# Altium schematic sheet Schema.SchDoc
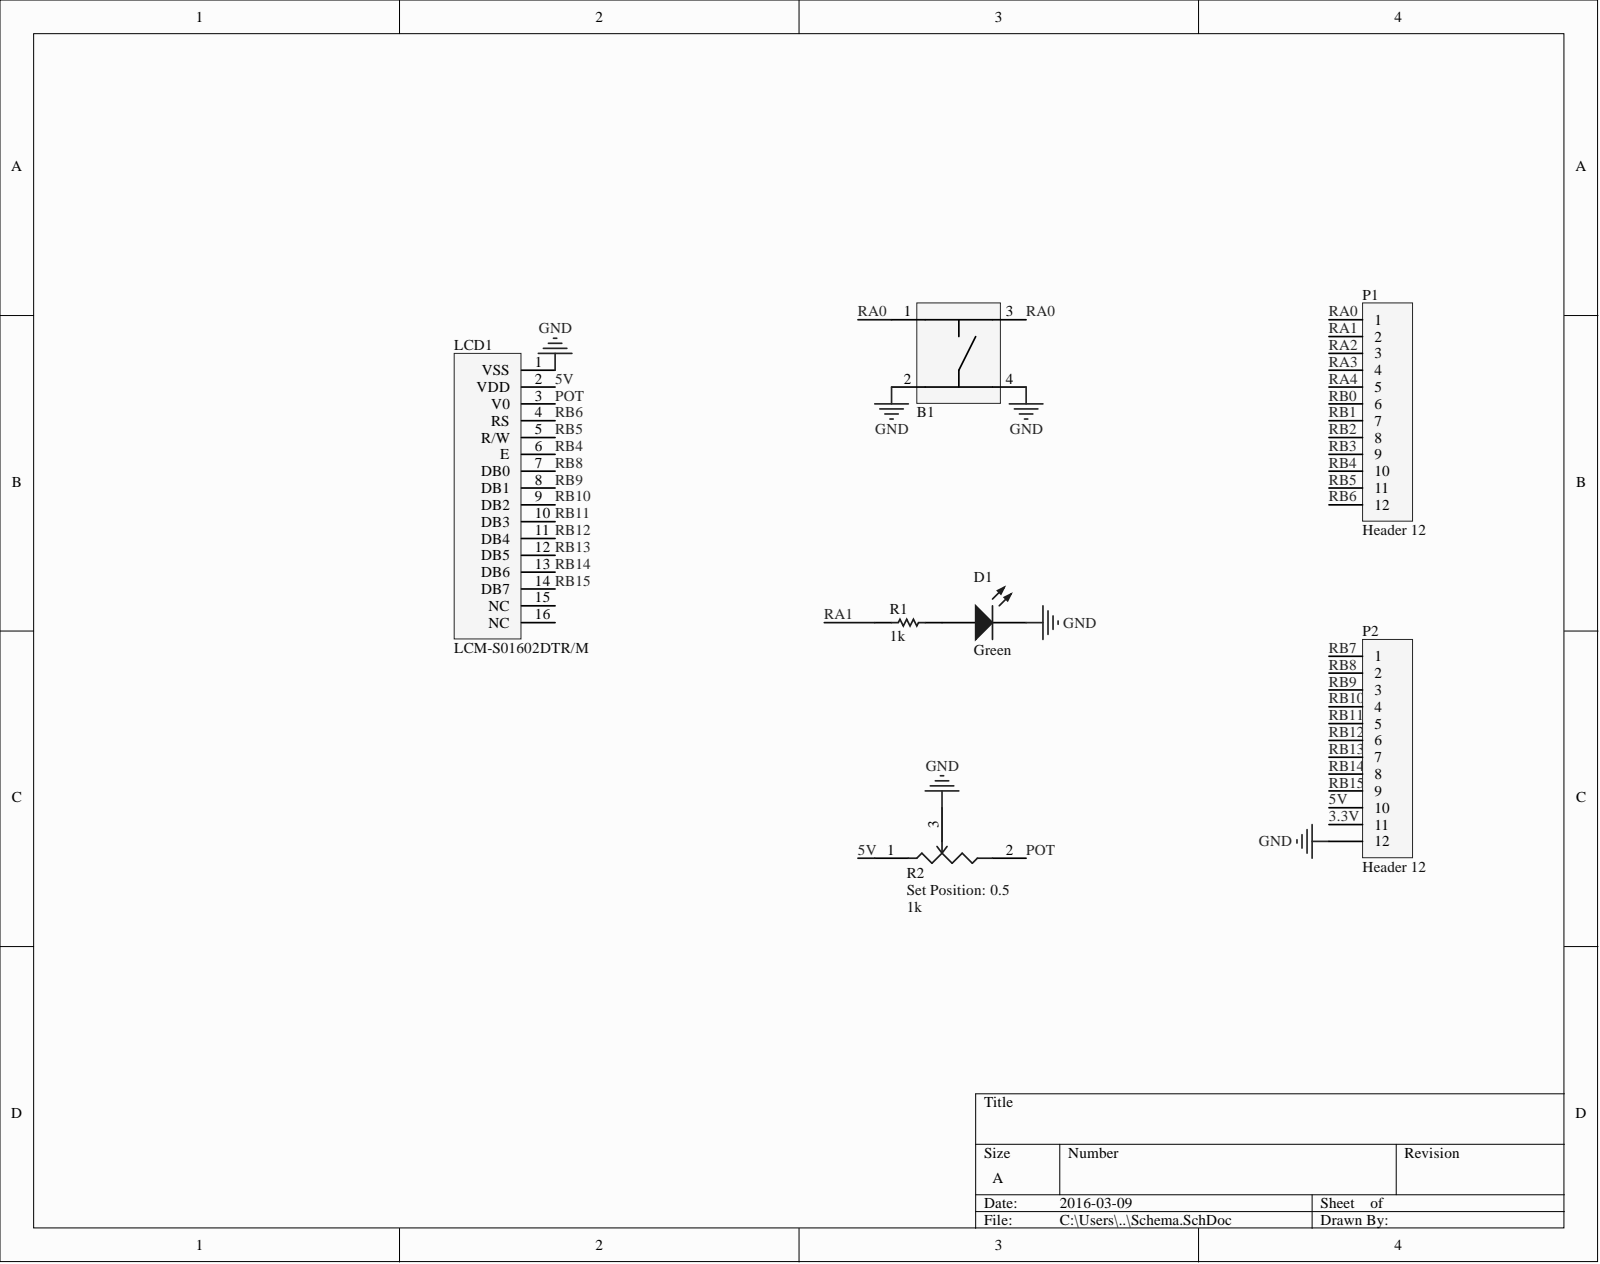
[diagram p0: Schema.SchDoc]

## Parts
B1  BoutonPoussoir  [BoutonPoussoirMini]  (value not drawn)
D1  Color: Green  [LED_GREEN]  (hidden comment: LED_GREEN)
LCD1  LCM-S01602DTR/M  [LCM-S01602DTR/M]
P1  Header 12  [HDR1X12]
P2  Header 12  [HDR1X12]
R1  value 1k  [RES1206R]  (hidden comment: Res)
R2  value 1k  Set Position: 0.5  [Potentiometer]  (hidden comment: ResVar)

## Nets
GND: B1.2 B1.4 D1.2 LCD1.1(VSS) P2.12 R2.3
3.3V: P2.11
5V: LCD1.2(VDD) P2.10 R2.1
POT: LCD1.3(V0) R2.2
RA0: B1.1 B1.3 P1.1
RA1: P1.2 R1.1
RA2: P1.3
RA3: P1.4
RA4: P1.5
RB0: P1.6
RB1: P1.7
RB2: P1.8
RB3: P1.9
RB4: LCD1.6(E) P1.10
RB5: LCD1.5(R/W) P1.11
RB6: LCD1.4(RS) P1.12
RB7: P2.1
RB8: LCD1.7(DB0) P2.2
RB9: LCD1.8(DB1) P2.3
RB10: LCD1.9(DB2) P2.4
RB11: LCD1.10(DB3) P2.5
RB12: LCD1.11(DB4) P2.6
RB13: LCD1.12(DB5) P2.7
RB14: LCD1.13(DB6) P2.8
RB15: LCD1.14(DB7) P2.9
NetD1_1: D1.1 R1.2

Not connected: LCD1 15(NC) 16(NC)

## Library data of the parts
B1 = BoutonPoussoir: Switch Tactile SPST-NO; Component Kind: Standard; Library Name: AnalogDevices.IntLib; Supplier 1: Digi-Key; Supplier Part Number 1: SW422-ND
D1 = LED_GREEN: Typical Green LED; Component Kind: Standard; LatestRevisionDate: 08-Jul-2005; LatestRevisionNote: Mech Layer 1 removed.; Library Name: AnalogDevices.IntLib; PackageDescription: LED; 2 Leads; PackageReference: LED-0; Published: 8-Jun-2000; Simulation: LED0; Supplier 1: Digi-Key; Supplier Part Number 1: 160-1852-ND; Publisher: Altium Limited
LCD1 = LCM-S01602DTR/M: LCD MODULE 16X2 CHARACTER; Component Kind: Standard; LatestRevisionDate: 17-Jul-2002; LatestRevisionNote: Re-released for DXP Platform.; Library Name: IntegratedCircuits.IntLib; Signal Integrity: Connector; Supplier 1: Digi-Key; Publisher: Altium Limited
P1 P2 = Header 12: Header, 12-Pin; Component Kind: Standard; LatestRevisionDate: 17-Jul-2002; LatestRevisionNote: Re-released for DXP Platform.; Library Name: Connectors.IntLib; Signal Integrity: Connector; Publisher: Altium Limited
R1 = Res: Resistor; Component Kind: Standard; LatestRevisionDate: 17-Jul-2002; LatestRevisionNote: Re-released for DXP Platform.; Library Name: Resistors.IntLib; PackageDescription: Axial Device, Thru-Hole; 2 Leads; 0.3 in Pin Spacing; PackageReference: AXIAL-0.3; Published: 8-Jun-2000; Signal Integrity: Res; Simulation: RESISTOR; Publisher: Altium Limited
R2 = ResVar: Potentiometer; Sim Note: Enter the Resistance value and the set point into parameter fields.; Component Kind: Standard; LatestRevisionDate: 17-Jul-2002; LatestRevisionNote: Re-released for DXP Platform.; Library Name: AnalogDevices.IntLib; PackageDescription: Variable Resistor, Thru-Hole; 3 Leads; PackageReference: VR3; Published: 24-Mar-1999; Signal Integrity: Res Tap; Simulation: POT; Supplier 1: Digi-Key; Supplier Part Number 1: 3386P-102LF-ND; Publisher: Altium Limited
